# Altium schematic sheet 3G 蓝牙 WIFI.SchDoc("3G 蓝牙 WIFI")
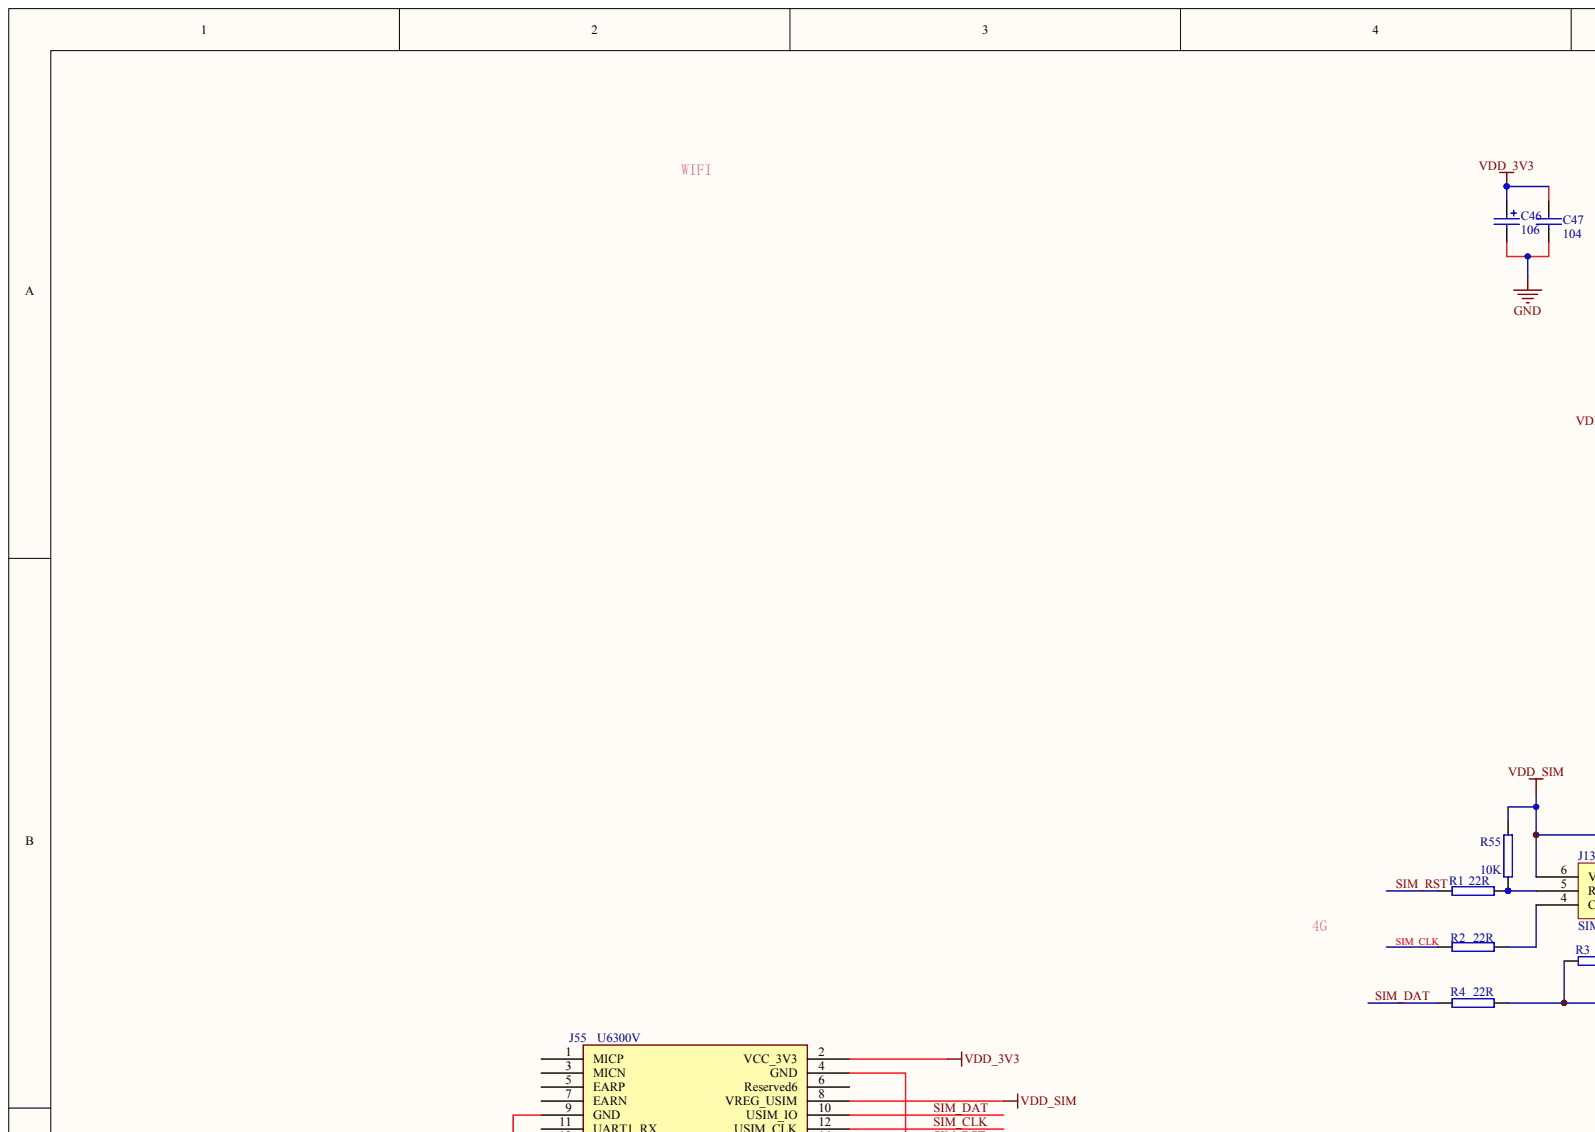
[diagram p0: 3G 蓝牙 WIFI.SchDoc, top left]
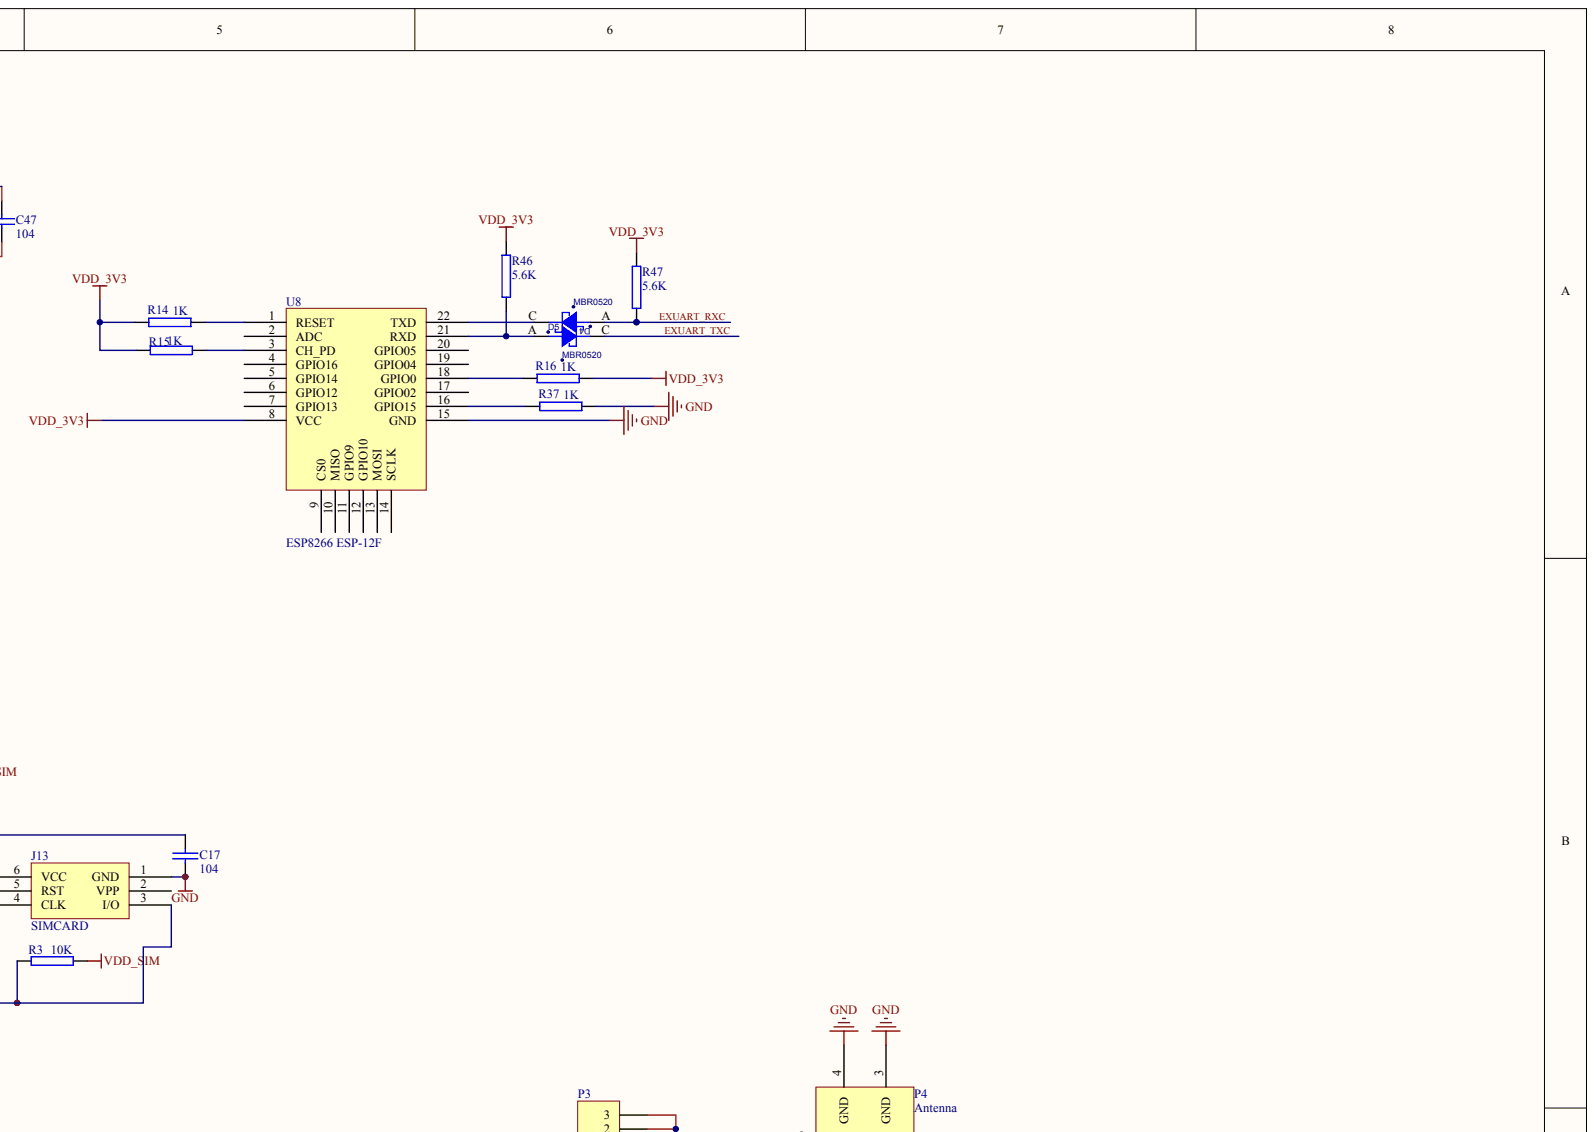
[diagram p1: 3G 蓝牙 WIFI.SchDoc, top right]
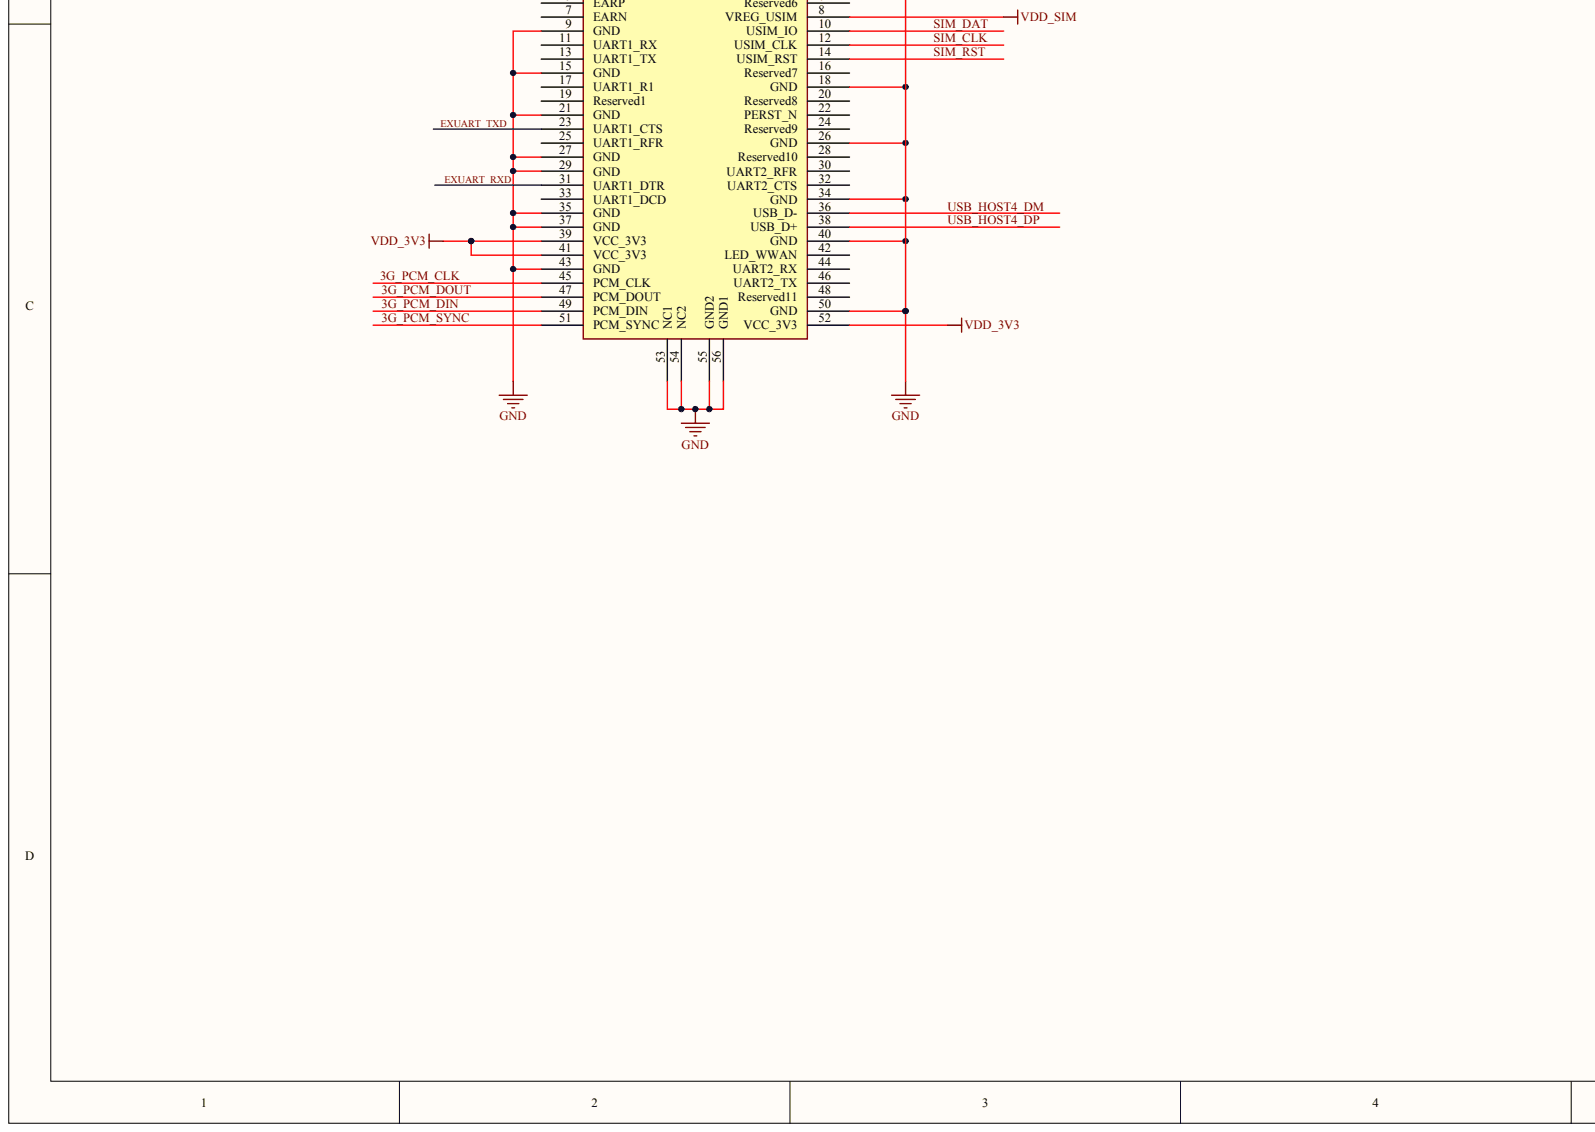
[diagram p2: 3G 蓝牙 WIFI.SchDoc, bottom left]
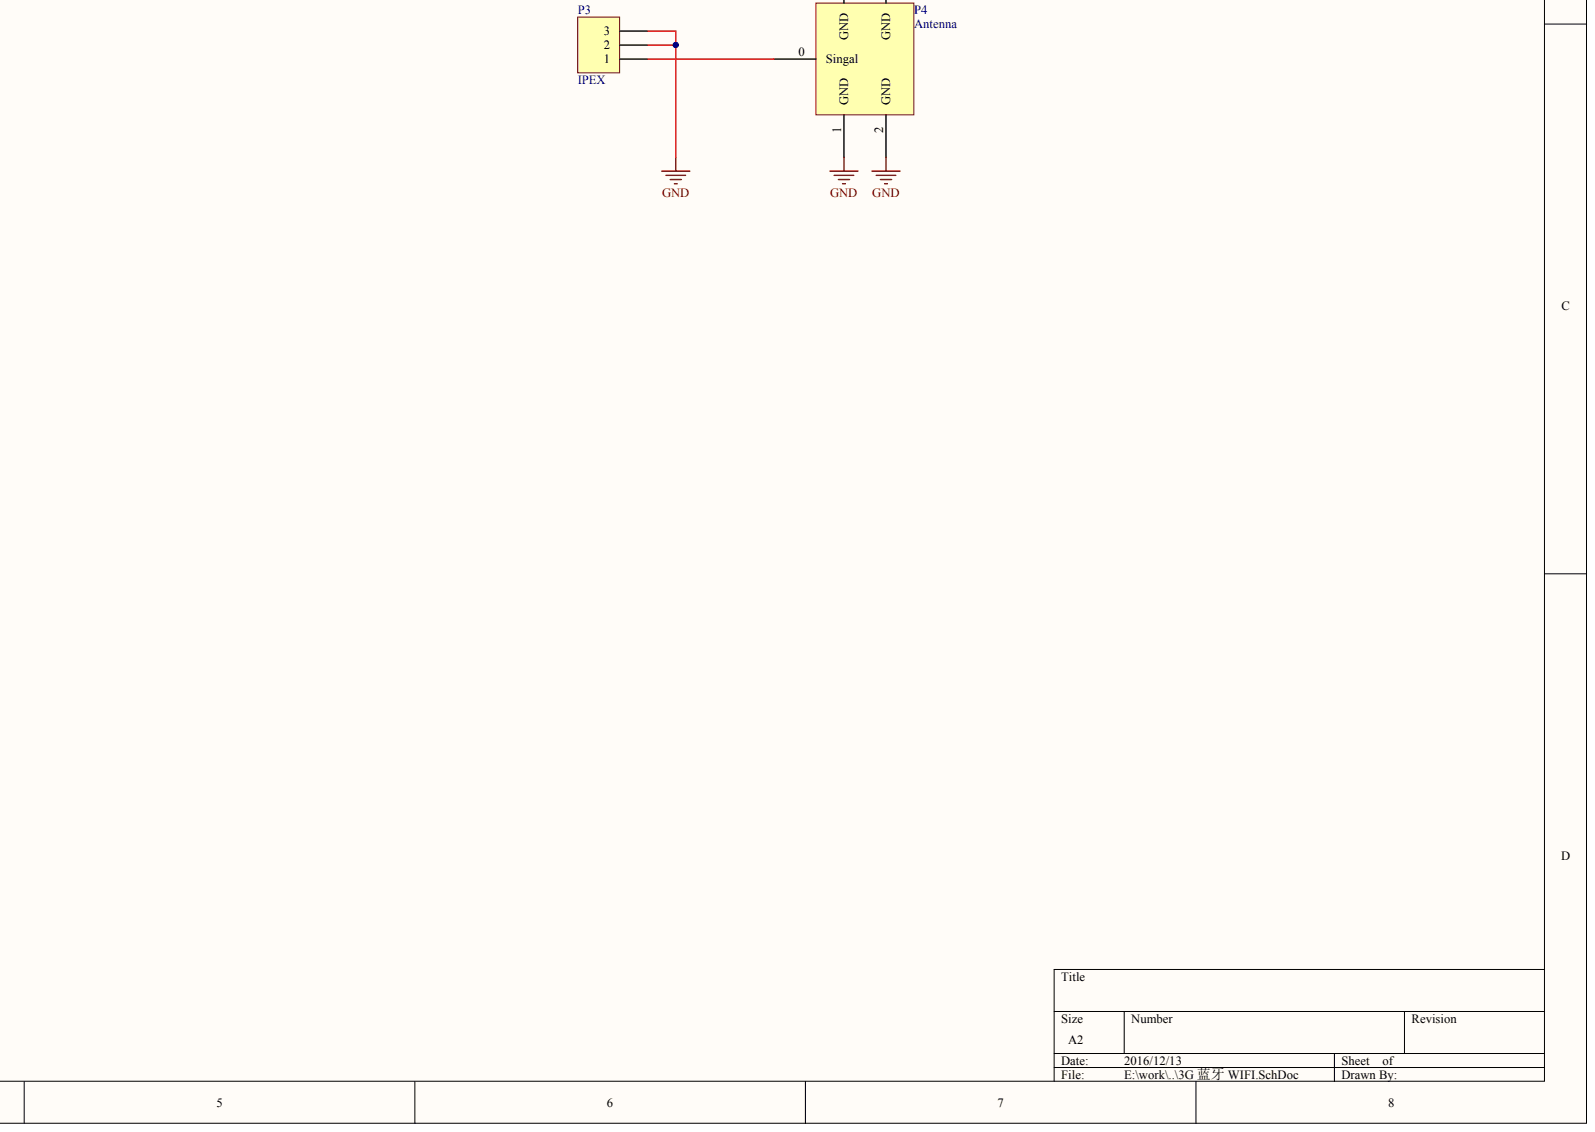
[diagram p3: 3G 蓝牙 WIFI.SchDoc, bottom right]

## Parts
C17  104
C46  106
C47  104
D4  MBR0520
D5  MBR0520
J13  SIMCARD
J55  U6300V
P3  IPEX
P4  Antenna
R1  22R
R2  22R
R3  10K
R4  22R
R14  1K
R15  1K
R16  1K
R37  1K
R46  5.6K
R47  5.6K
R55  10K
U8  ESP8266 ESP-12F

## Nets
GND: C17.1 C46.2 C47.2 J13.1(GND) J55.4(GND) J55.9(GND) J55.15(GND) J55.18(GND) J55.21(GND) J55.26(GND) J55.27(GND) J55.29(GND) J55.34(GND) J55.35(GND) J55.37(GND) J55.40(GND) J55.43(GND) J55.50(GND) J55.53(NC1) J55.54(NC2) J55.55(GND2) J55.56(GND1) P3.2 P3.3 P4.1(GND) P4.2(GND) P4.3(GND) P4.4(GND) R37.2 U8.15(GND)
VDD_3V3: C46.1 C47.1 J55.2(VCC_3V3) J55.39(VCC_3V3) J55.41(VCC_3V3) J55.52(VCC_3V3) R14.1 R15.1 R16.2 R46.2 R47.2 U8.8(VCC)
VDD_SIM: C17.2 J13.6(VCC) J55.8(VREG_USIM) R3.1 R55.1
3G_PCM_CLK: J55.45(PCM_CLK)
3G_PCM_DIN: J55.49(PCM_DIN)
3G_PCM_DOUT: J55.47(PCM_DOUT)
3G_PCM_SYNC: J55.51(PCM_SYNC)
EXUART_RXC: D4.A R47.1
EXUART_RXD: J55.31(UART1_DTR)
EXUART_TXC: D5.C
EXUART_TXD: J55.23(UART1_CTS)
SIM_CLK: J55.12(USIM_CLK) R2.1
SIM_DAT: J55.10(USIM_IO) R4.2
SIM_RST: J55.14(USIM_RST) R1.1
USB_HOST4_DM: J55.36(USB_D-)
USB_HOST4_DP: J55.38(USB_D+)
NetD4_C: D4.C U8.22(TXD)
NetD5_A: D5.A R46.1 U8.21(RXD)
NetJ13_3: J13.3(I/O) R3.2 R4.1
NetJ13_4: J13.4(CLK) R2.2
NetJ13_5: J13.5(RST) R1.2 R55.2
NetP3_1: P3.1 P4.0(Singal)
NetR14_2: R14.2 U8.1(RESET)
NetR15_2: R15.2 U8.3(CH_PD)
NetR16_1: R16.1 U8.18(GPIO0)
NetR37_1: R37.1 U8.16(GPIO15)

Not connected: J13 2(VPP); J55 1(MICP) 3(MICN) 5(EARP) 6(Reserved6) 7(EARN) 11(UART1_RX) 13(UART1_TX) 16(Reserved7) 17(UART1_R1) 19(Reserved1) 20(Reserved8) 22(PERST_N) 24(Reserved9) 25(UART1_RFR) 28(Reserved10) 30(UART2_RFR) 32(UART2_CTS) 33(UART1_DCD) 42(LED_WWAN) 44(UART2_RX) 46(UART2_TX) 48(Reserved11); U8 2(ADC) 4(GPIO16) 5(GPIO14) 6(GPIO12) 7(GPIO13) 9(CS0) 10(MISO) 11(GPIO9) 12(GPIO10) 13(MOSI) 14(SCLK) 17(GPIO02) 19(GPIO04) 20(GPIO05)

## Library data of the parts
D4 D5 = (no symbol name): CLASS: IC; FPLIST: sod123lf,SOD123; CAD_APPROVED: Yes; MFG_PN01: MBR140SFT1G; MFG_NAME01: ON SEMICONDUCTOR; AGILE_PN: 480-30006; HEIGHT: 1.0 MM; ROHS: Yes
C17 C46 C47 J13 J55 P3 P4 R1-R4 R14-R16 R37 R46 R47 R55 U8 = (no symbol name)
  P3: LatestRevisionDate: 17-Jul-2002; LatestRevisionNote: Re-released for DXP Platform.; Publisher: Altium Limited
